# Altium schematic sheet 11_Power.SchDoc(11_Power) (continued)

## Library data of the parts
C224 C225 C234 C235 C243 C244 C246 C250 C251 = Cap_0402: Part Description: 4.7µF ±20% 6.3V X5R Ceramic Capacitor -55°C ~ 85°C Surface Mount, MLCC 0402 (1005 Metric) 0.039" L x 0.020" W (1.00mm x 0.50mm); Manufacturer Part Number 1: GRM155R60J475ME87D; Manufacturer: Murata Electronics North America; Tolerance: ±20%; Temperature Coefficient: X5R; Capacitance: 4.7µF; Voltage - Rated: 6.3V; Value: 4.7uF, 6.3V; Thickness (Max): 0.022" (0.55mm); Supplier Part Number 1: 490-5408-1-ND; Supplier 1: Digi-Key; Size / Dimension: 0.039" L x 0.020" W (1.00mm x 0.50mm); RoHS: RoHS Compliant; Ratings: -; Packaging: Cut Tape (CT); Package / Case: 0402 (1005 Metric); Operating Temperature: -55°C ~ 85°C; Mounting Type: Surface Mount, MLCC; Lead Style: -; Lead Spacing: -; Height - Seated (Max): -; Features: Derating Recommended; Component Kind: Standard; Category: Capacitors; Applications: General Purpose; Library Name: LimeMicroAltiumLib_schLib.SchLib
C226 = Cap_0402: Part Description: 1µF ±10% 10V X5R Ceramic Capacitor -55°C ~ 85°C Surface Mount, MLCC 0402 (1005 Metric) 0.039" L x 0.020" W (1.00mm x 0.50mm); Manufacturer Part Number 1: C1005X5R1E105K050BC; Manufacturer: TDK; Voltage Rating: 10; Product Range: TDK - C Series; Dielectric Characteristic: X6; Ceramic Capacitor Case: 0402 [1005 Metric]; Capacitance Tolerance: ± 10%; Capacitance: 1; Value: 1uF, 10V; Supplier Part Number 1: 445-9066-1-ND; Supplier 1: Digi-Key; RoHS: YES; MSL: -; Component Kind: Standard; Library Name: LimeMicroAltiumLib_schLib.SchLib
C227 C231 C233 C236 C238 C247 C249 C253 C254 C257 = Cap_0603: Part Description: 10 µF ±20% 10V Ceramic Capacitor X5R 0603 (1608 Metric); Manufacturer Part Number 1: GRM188R61A106ME69D; Manufacturer: Murata; Tolerance: 20%; Material: Ceramic; Dielectric: X5R; Width: 0.8mm; Weight: 0.000222oz; Voltage Rating: 10V; Value: 10uF, 10V; Thickness: 0.035inch; Termination: SMD/SMT; Supplier Part Number 1: 490-10475-1-ND; Supplier 1: Digi-Key; Stock 1: 820978; Series: GRM; RoHS Compliant: Yes; RoHS: Yes; Pricing 1: 1=0,16000, 10=0,11200, 50=0,06360, 100=0,05270, 500=0,03696, 1000=0,03060 (USD); Packaging: Tape and Reel; Package Quantity: 4000; Mount: Surface Mount; Min Operating Temperature: -55°C; Max Operating Temperature: 85°C; Length: 1.6mm; Lead Free: Lead Free; Height: 0.8mm; Depth: 0.8mm; ComponentLink9URL: http://search.murata.co.jp/Ceramy/image/img/A01X/G101/ENG/GRM188R61A106ME69-01.pdf?src-supplier=Digi-Key; ComponentLink9Description: http://search.murata.co.jp/Ceramy/image/img/A01X/G101/ENG/GRM188R61A106ME69-01.pdf?src-supplier=Digi-Key; ComponentLink8URL: http://www.farnell.com/datasheets/1872210.pdf?src-supplier=Element14; ComponentLink8Description: http://www.farnell.com/datasheets/1872210.pdf?src-supplier=Element14; ComponentLink7URL: http://www.farnell.com/datasheets/2048014.pdf?src-supplier=Element14; ComponentLink7Description: http://www.farnell.com/datasheets/2048014.pdf?src-supplier=Element14; ComponentLink6URL: http://www.farnell.com/datasheets/1872210.pdf?src-supplier=Newark; ComponentLink6Description: http://www.farnell.com/datasheets/1872210.pdf?src-supplier=Newark; ComponentLink5URL: http://www.mouser.com/ds/2/281/c02e-2905.pdf?src-supplier=Mouser; ComponentLink5Description: http://www.mouser.com/ds/2/281/c02e-2905.pdf?src-supplier=Mouser; ComponentLink4URL: http://www.farnell.com/datasheets/1872210.pdf?src-supplier=Farnell; ComponentLink4Description: http://www.farnell.com/datasheets/1872210.pdf?src-supplier=Farnell; ComponentLink3URL: http://search.murata.co.jp/Ceramy/image/img/A01X/partnumbering_e_01.pdf?src-supplier=Digi-Key; ComponentLink3Description: http://search.murata.co.jp/Ceramy/image/img/A01X/partnumbering_e_01.pdf?src-supplier=Digi-Key; ComponentLink2URL: https://search.murata.co.jp/Ceramy/image/img/A01X/G101/ENG/GRM188R61C106MAAL-01.pdf?src-supplier=Digi-Key; ComponentLink2Description: https://search.murata.co.jp/Ceramy/image/img/A01X/G101/ENG/GRM188R61C106MAAL-01.pdf?src-supplier=Digi-Key; ComponentLink1URL: http://search.murata.co.jp/Ceramy/image/img/A01X/G101/ENG/GRM188R61C106MAAL-01.pdf?src-supplier=Digi-Key; ComponentLink1Description: http://search.murata.co.jp/Ceramy/image/img/A01X/G101/ENG/GRM188R61C106MAAL-01.pdf?src-supplier=Digi-Key; Component Kind: Standard; Case Code (Metric): 1608; Case Code (Imperial): 0603; Case/Package: 0603; Capacitance: 10uF; Library Name: LimeFPGAboard_schLib.SchLib
  C227 C247: Voltage Rating (DC): 10V
C228 C229 C240 C258 = Cap_0402: Part Description: 0.1 µF ±20% 10V Ceramic Capacitor X5R 0201 (0603 Metric); Manufacturer Part Number 1: CL03A104MP3NNNC; Manufacturer: Samsung; Tolerance: 20%; Width: 0.012inch; Voltage Rating: 10V; Value: 100nF, 10V; Thickness: 0.013inch; Supplier Part Number 1: 1276-1321-1-ND; Supplier 1: Digi-Key; Stock 1: 3817176; Series: CL; RoHS Compliant: Yes; RoHS: Yes; Pricing 1: 1=0,10000, 10=0,03000, 50=0,01640, 100=0,01330, 500=0,00950, 1000=0,00747, 2500=0,00679, 5000=0,00625 (USD); Packaging: Tape and Reel; Package Quantity: 1; Mount: Surface Mount; Min Operating Temperature: -55°C; Max Operating Temperature: 85°C; Length: 0.024inch; ComponentLink5URL: https://datasheet.ciiva.com/25788/cl03a104mp3nnnc-25788272.pdf?src-supplier=Digi-Key; ComponentLink5Description: https://datasheet.ciiva.com/25788/cl03a104mp3nnnc-25788272.pdf?src-supplier=Digi-Key; Component Kind: Standard; Case Code (Metric): 0603; Case Code (Imperial): 0201; Case/Package: 0201; Capacitance: 100nF; Library Name: LimeMicroAltiumLib_schLib.SchLib
C230 C239 C245 C256 = Cap_0402: Part Description: 100 pF ±5% 50V Ceramic Capacitor C0G, NP0 0201 (0603 Metric); Manufacturer Part Number 1: C0603C0G1H101J030BA; Manufacturer: TDK; Tolerance: 5%; Insulation Resistance: 10GR; Dielectric Material: Ceramic; Dielectric: C0G; Width: 0.012inch; Weight: 6E-06oz; Voltage Rating: 50V; Voltage: 50V; Value: 100pF, 50V; Thickness: 0.013inch; Termination: SMD/SMT; Supplier Part Number 1: 445-1786-1-ND; Supplier 1: Digi-Key; Stock 1: 367528; Series: C; RoHS Compliant: Yes; RoHS: Yes; Reach SVHC Compliant: Yes; Pricing 1: 1=0,10000, 10=0,06000, 50=0,03280, 100=0,02670, 500=0,01908, 1000=0,01500, 2500=0,01364, 5000=0,01254 (USD); Packaging: Tape and Reel; Package Quantity: 1; Number of Pins: 2; Mount: Surface Mount; Min Operating Temperature: -55°C; Max Operating Temperature: 125°C; Length: 0.6mm; Lead Free: Lead Free; Height: 0.3mm; Depth: 0.3mm; Construction: Flat; ComponentLink3URL: https://datasheet.ciiva.com/6250/tdkmlcccaprange-6250351.pdf?src-supplier=Digi-Key; ComponentLink3Description: https://datasheet.ciiva.com/6250/tdkmlcccaprange-6250351.pdf?src-supplier=Digi-Key; ComponentLink2URL: https://datasheet.ciiva.com/16205/mlcc-commercial-general-en-16205590.pdf?src-supplier=Future+Electronics; ComponentLink2Description: https://datasheet.ciiva.com/16205/mlcc-commercial-general-en-16205590.pdf?src-supplier=Future+Electronics; ComponentLink1URL: https://datasheet.ciiva.com/24217/c0603c0g1h101j030ba-264106-24217425.pdf?src-supplier=Mouser; ComponentLink1Description: https://datasheet.ciiva.com/24217/c0603c0g1h101j030ba-264106-24217425.pdf?src-supplier=Mouser; Component Kind: Standard; Case Code (Metric): 0603; Case Code (Imperial): 0201; Case/Package: 0201; Capacitance: 100pF; Library Name: LimeMicroAltiumLib_schLib.SchLib
C232 C237 C241 C242 C252 C255 C259 C260 = Cap_0603: Part Description: 47 µF ±20% 6.3V Ceramic Capacitor X5R 0603 (1608 Metric); Manufacturer Part Number 1: GRM188R60J476ME15D; Manufacturer: Murata; Tolerance: 20%; Dielectric: X5R; Width: 0.031inch; Voltage Rating: 6.3V; Value: 47uF, 6.3V; Thickness: 0.039inch; Supplier Part Number 1: 490-13247-1-ND; Supplier 1: Digi-Key; Stock 1: 731453; RoHS Compliant: Yes; RoHS: Yes; Pricing 1: 1=0,45000, 10=0,31900, 50=0,24120, 100=0,21000, 500=0,15556, 1000=0,13223 (USD); Packaging: Tape and Reel; Mount: Surface Mount; Min Operating Temperature: -55°C; Max Operating Temperature: 85°C; Length: 0.063inch; ComponentLink2URL: https://www.murata.com/~/media/webrenewal/support/library/catalog/products/capacitor/mlcc/c02e.ashx?la=en-us&src-supplier=Digi-Key; ComponentLink2Description: https://www.murata.com/~/media/webrenewal/support/library/catalog/products/capacitor/mlcc/c02e.ashx?la=en-us&src-supplier=Digi-Key; ComponentLink1URL: http://search.murata.co.jp/Ceramy/image/img/A01X/G101/ENG/GRM188R60J476ME15-01.pdf?src-supplier=Digi-Key; ComponentLink1Description: http://search.murata.co.jp/Ceramy/image/img/A01X/G101/ENG/GRM188R60J476ME15-01.pdf?src-supplier=Digi-Key; Component Kind: Standard; Case Code (Metric): 1608; Case Code (Imperial): 0603; Case/Package: 0603; Capacitance: 47uF; Library Name: LimeFPGAboard_schLib.SchLib
C248 C261 C262 = Cap_0402: Part Description: 1µF ±20% 6.3V X5R Ceramic Capacitor -55°C ~ 85°C Surface Mount, MLCC 0201 (0603 Metric) 0.024" L x 0.012" W (0.60mm x 0.30mm); Manufacturer Part Number 1: JMK063ABJ105MP-F; Manufacturer: TDK Corporation; Value: 1uF, 20%; Tolerance: ±20%; Temperature Coefficient: X5R; Capacitance: 1.0µF; Thickness (Max): -; Supplier Part Number 1: 587-5864-2-ND; Supplier 1: Digi-Key; Size / Dimension: 0.024" L x 0.012" W (0.60mm x 0.30mm); RoHS: RoHS Compliant; Ratings: -; Packaging: Cut Tape (CT); Package / Case: 0201 (0603 Metric); Operating Temperature: -55°C ~ 85°C; Mounting Type: Surface Mount, MLCC; Lead Style: -; Lead Spacing: -; Height - Seated (Max): -; Features: -; Component Kind: Standard; Category: Capacitors; Applications: General Purpose; Library Name: LimeMicroAltiumLib_schLib.SchLib
FR44 FR46-FR50 = Ferrite: Part Description: FERRITE BEAD 220 OHM 0402 1LN; Manufacturer Part Number 1: MPZ1005S221ET000; Manufacturer: TDK Corporation; Impedance @ Frequency: 220 Ohm @ 100MHz; DC Resistance (DCR): 220 mOhm Max; Current Rating: 900mA; Value: 220R, 0.9A; Supplier Part Number 1: 445-9329-2-ND; Supplier 1: Digi-Key; Package / Case: 0402 (1005 Metric); Component Kind: Standard; Category: Filters; Library Name: LimeFPGAboard_schLib.SchLib
FR45 = Ferrite: Part Description: FERRITE BEAD 600 OHM 0805 1LN; Manufacturer Part Number 1: MPZ2012S601AT000; Manufacturer: TDK Corporation; Impedance @ Frequency: 600 Ohm @ 100MHz; Filter Type: Differential Mode - Single; DC Resistance (DCR): 100 mOhm Max; Supplier Part Number 1: 445-2206-2-ND; Supplier 1: Digi-Key; Size / Dimension: 0.079" L x 0.049" W (2.00mm x 1.25mm); RoHS: RoHS Compliant; Packaging: Tape & Reel (TR); Package / Case: 0805 (2012 Metric); Mounting Type: Surface Mount; Height (Max): 0.041" (1.05mm); Component Kind: Standard; Category: Filters; Library Name: LimeMicroAltiumLib_schLib.SchLib
IC22 IC26-IC28 = LD39100PUR: Part Description: Linear Voltage Regulator IC Positive Adjustable Output 0.8 V ~ 4.5 V 1A 6-DFN (3x3); Manufacturer: STMicroelectronics; Voltage Dropout (Max): 0.4V @ 1A; Voltage - Output (Min/Fixed): 0.8V; Voltage - Output (Max): 4.6V; Voltage - Input (Max): 5.5V; PSRR: 70dB ~ 65dB (1kHz ~ 10kHz); Protection Features: Over Current, Over Temperature, Short Circuit; Output Type: Adjustable; Output Configuration: Positive; Current - Supply: 50µA ~ 300µA; Current - Output: 1A; Control Features: Enable, Power Good; Supplier Part Number 1: 497-11013-1-ND; Supplier Device Package: 6-DFN (3x3); Supplier 1: Digi-Key; Stock 1: 27970; RoHS: RoHS Compliant; Pricing 1: 1=0.85, 10=0.76, 100=0.5923, 500=0.48926, 1000=0.38625 (USD); Part Status: Active; Packaging: Cut Tape (CT); Package / Case: 6-VDFN Exposed Pad; Operating Temperature: -40°C ~ 125°C; Mounting Type: Surface Mount; Manufacturer Part Number 1: LD39100PUR; ComponentLink1URL: http://www.st.com/content/ccc/resource/technical/document/datasheet/72/02/e5/ee/df/36/40/5e/CD00233788.pdf/files/CD00233788.pdf/jcr:content/translations/en.CD00233788.pdf; ComponentLink1Description: http://www.st.com/content/ccc/resource/technical/document/datasheet/72/02/e5/ee/df/36/40/5e/CD00233788.pdf/files/CD00233788.pdf/jcr:content/translations/en.CD00233788.pdf; Component Kind: Standard; Category: Integrated Circuits (ICs); Library Name: LimeMicroAltiumLib_schLib.SchLib
IC23 = 74LVC1G3157FZ4_SPDT_SW: Part Description: 1 Circuit IC Switch 2:1 10Ohm X2-DFN1410-6; Manufacturer Part Number 1: 74LVC1G3157FZ4-7; Manufacturer: Diodes; On-State Resistance: 10R; Number of Circuits: 1; -3db Bandwidth: 300MHz; Supplier Part Number 1: 74LVC1G3157FZ4-7DICT-ND; Supplier 1: Digi-Key; Stock 1: 158709; RoHS Compliant: Yes; RoHS: Yes; Pricing 1: 1=0,42000, 10=0,31200, 25=0,27320, 100=0,17670, 250=0,14628, 500=0,11702, 1000=0,08972, 2500=0,07802 (USD); Packaging: Tape and Reel; Number of Pins: 6; Min Operating Temperature: -40°C; Max Operating Temperature: 125°C; ComponentLink2URL: https://www.diodes.com/assets/product-showcases/74LVC3157-NPA-0516.pdf?src-supplier=Digi-Key; ComponentLink2Description: https://www.diodes.com/assets/product-showcases/74LVC3157-NPA-0516.pdf?src-supplier=Digi-Key; ComponentLink1URL: https://www.diodes.com/assets/Datasheets/74LVC1G3157.pdf?src-supplier=Digi-Key; ComponentLink1Description: https://www.diodes.com/assets/Datasheets/74LVC1G3157.pdf?src-supplier=Digi-Key; Component Kind: Standard; Library Name: LimeMicroAltiumLib_schLib_r04.SchLib
IC24 = VOLTAGE_REFERENCE_UNIVERSAL: Part Description: Shunt Voltage Reference IC Adjustable 2.5V 36 VV ±0.5% 100 mA SOT-23-3; Manufacturer Part Number 1: AS431ANTR-G1; Manufacturer: Diodes; Value: AS431; Supplier Part Number 1: AS431ANTR-G1DICT-ND; Supplier 1: Digikey; Stock 1: 655820; RoHS: Yes; Pricing 1: 1=0,32, 10=0,196, 25=0,162, 100=0,1226, 250=0,10304, 500=0,09098, 1000=0,08085 (USD); ComponentLink7URL: https://datasheet.ciiva.com/29572/as431-29572150.pdf?src-supplier=Digikey; ComponentLink7Description: https://datasheet.ciiva.com/29572/as431-29572150.pdf?src-supplier=Digikey; ComponentLink6URL: https://datasheet.ciiva.com/29572/as431-29572149.pdf?src-supplier=Digikey; ComponentLink6Description: https://datasheet.ciiva.com/29572/as431-29572149.pdf?src-supplier=Digikey; ComponentLink5URL: https://datasheet.ciiva.com/8132/lm4040-8132551.pdf?src-supplier=Avnet; ComponentLink5Description: https://datasheet.ciiva.com/8132/lm4040-8132551.pdf?src-supplier=Avnet; ComponentLink4URL: https://datasheet.ciiva.com/29572/as431-29572150.pdf?src-supplier=Digi-Key; ComponentLink4Description: https://datasheet.ciiva.com/29572/as431-29572150.pdf?src-supplier=Digi-Key; ComponentLink3URL: https://datasheet.ciiva.com/29572/as431-29572149.pdf?src-supplier=Digi-Key; ComponentLink3Description: https://datasheet.ciiva.com/29572/as431-29572149.pdf?src-supplier=Digi-Key; ComponentLink2URL: https://datasheet.ciiva.com/30002/as431-30002029.pdf?src-supplier=Avnet; ComponentLink2Description: https://datasheet.ciiva.com/30002/as431-30002029.pdf?src-supplier=Avnet; ComponentLink1URL: https://datasheet.ciiva.com/1177/1643148-1177233.pdf?src-supplier=Avnet; ComponentLink1Description: https://datasheet.ciiva.com/1177/1643148-1177233.pdf?src-supplier=Avnet; Component Kind: Standard; Library Name: LimeMicroAltiumLib_schLib_r05.SchLib
IC25 = LP8758A1E0YFFR_QUAD_DC-DC: Part Description: Buck Switching Regulator IC Positive Programmable 0.5V 4 Output 4A 35-UFBGA, DSBGA; Manufacturer: Texas Instruments; Supplier Part Number 1: 296-LP8758A2E3YFFRCT-ND; Supplier 1: Digi-Key; Stock 1: 5990; RoHS: Yes; Pricing 1: 1=5,83, 10=5,235, 25=4,9496, 100=4,2896, 250=4,0696, 500=3,65164, 1000=3,07969 (USD); Manufacturer Part Number 1: LP8758A2E3YFFR; ComponentLink3URL: http://www.ti.com/lit/ds/symlink/lp8758-e0.pdf?src-supplier=Digi-Key; ComponentLink3Description: http://www.ti.com/lit/ds/symlink/lp8758-e0.pdf?src-supplier=Digi-Key; ComponentLink2URL: http://www.ti.com/lit/gpn/lp8758-e0?src-supplier=Mouser; ComponentLink2Description: http://www.ti.com/lit/gpn/lp8758-e0?src-supplier=Mouser; ComponentLink1URL: http://www.ti.com/general/docs/suppproductinfo.tsp?distId=10&amp;gotoUrl=http%3A%2F%2Fwww.ti.com%2Flit%2Fgpn%2Flp8758-e0&src-supplier=Digi-Key; ComponentLink1Description: http://www.ti.com/general/docs/suppproductinfo.tsp?distId=10&amp;gotoUrl=http%3A%2F%2Fwww.ti.com%2Flit%2Fgpn%2Flp8758-e0&src-supplier=Digi-Key; Component Kind: Standard; Library Name: LimeMicroAltiumLib_schLib_r04.SchLib
IC29 = LP8758A1E0YFFR_QUAD_DC-DC: Part Description: Switching Voltage Regulators Four-phase, four 4A output DC-DC step-down converters 35-DSBGA -40 to 85; Manufacturer: Texas Instruments; Supplier Part Number 1: 296-LP8758A2E3YFFRCT-ND; Supplier 1: Digi-Key; Stock 1: 5990; RoHS: Yes; Pricing 1: 1=5,83, 10=5,235, 25=4,9496, 100=4,2896, 250=4,0696, 500=3,65164, 1000=3,07969 (USD); Manufacturer Part Number 1: LP8758A2E3YFFR; ComponentLink3URL: http://www.ti.com/lit/ds/symlink/lp8758-e0.pdf?src-supplier=Digi-Key; ComponentLink3Description: http://www.ti.com/lit/ds/symlink/lp8758-e0.pdf?src-supplier=Digi-Key; ComponentLink2URL: http://www.ti.com/lit/gpn/lp8758-e0?src-supplier=Mouser; ComponentLink2Description: http://www.ti.com/lit/gpn/lp8758-e0?src-supplier=Mouser; ComponentLink1URL: http://www.ti.com/general/docs/suppproductinfo.tsp?distId=10&amp;gotoUrl=http%3A%2F%2Fwww.ti.com%2Flit%2Fgpn%2Flp8758-e0&src-supplier=Digi-Key; ComponentLink1Description: http://www.ti.com/general/docs/suppproductinfo.tsp?distId=10&amp;gotoUrl=http%3A%2F%2Fwww.ti.com%2Flit%2Fgpn%2Flp8758-e0&src-supplier=Digi-Key; Component Kind: Standard; Library Name: LimeMicroAltiumLib_schLib_r04.SchLib
IC30 = AP7330-W5-7_LDO: Part Description: Linear Voltage Regulator IC Positive Adjustable 1 Output 300mA SOT-25; Manufacturer: Diodes; Supplier Part Number 1: AP7330-W5-7DICT-ND; Supplier 1: Digi-Key; Stock 1: 50531; RoHS: Yes; Pricing 1: 1=0,35, 10=0,286, 25=0,2616, 100=0,1946, 250=0,17632, 500=0,14592, 1000=0,10944 (USD); Manufacturer Part Number 1: AP7330-W5-7; ComponentLink2URL: https://www.diodes.com/assets/Datasheets/AP7330.pdf?src-supplier=Digi-Key; ComponentLink2Description: https://www.diodes.com/assets/Datasheets/AP7330.pdf?src-supplier=Digi-Key; ComponentLink1URL: https://datasheet.octopart.com/AP7330-W5-7-Diodes-Inc.-datasheet-149521347.pdf?src-supplier=Farnell; ComponentLink1Description: https://datasheet.octopart.com/AP7330-W5-7-Diodes-Inc.-datasheet-149521347.pdf?src-supplier=Farnell; Component Kind: Standard; Library Name: LimeMicroAltiumLib.SchLib
L2-L9 = Inductor: Part Description: 470 nH Shielded Drum Core, Wirewound Inductor 2.81 A 41mOhm Max 0806 (2016 Metric); Manufacturer Part Number 1: VLS201610HBX-R47M-1; Manufacturer: TDK; Tolerance: 20%; Test Frequency: 1MHz; Shielding: Shielded; Saturation Current: 3.5A; RMS Current (Irms): 3.3A; Inductance: 470nH; DC Resistance (DCR): 41mR; Current Rating: 2.81A; Core Material: Metal; Width: 0.063inch; Value: 0.47uH, 4A; Supplier Part Number 1: 445-172395-1-ND; Supplier 1: Digi-Key; Stock 1: 1817; RoHS Compliant: Yes; RoHS: Yes; Resistor Type: Wirewound; Reach SVHC Compliant: No SVHC; Pricing 1: 1=0,35000, 10=0,31200, 25=0,27320, 50=0,23400, 100=0,21450, 250=0,19504, 500=0,18334, 1000=0,14043 (USD); Packaging: Cut Tape; Mount: Surface Mount; Min Operating Temperature: -40°C; Max Operating Temperature: 105°C; Length: 0.079inch; Inductance Tolerance: 20%; Height - Seated (Max): 0.039inch; ComponentLink5URL: https://product.tdk.com/info/en/catalog/datasheets/inductor_commercial_power_vls201610hbx-1_en.pdf?ref_disty=digikey&src-supplier=Digi-Key; ComponentLink5Description: https://product.tdk.com/info/en/catalog/datasheets/inductor_commercial_power_vls201610hbx-1_en.pdf?ref_disty=digikey&src-supplier=Digi-Key; ComponentLink4URL: https://product.tdk.com/info/en/catalog/datasheets/inductor_commercial_power_vls201610hbx-1_en.pdf?src-supplier=Digi-Key; ComponentLink4Description: https://product.tdk.com/info/en/catalog/datasheets/inductor_commercial_power_vls201610hbx-1_en.pdf?src-supplier=Digi-Key; ComponentLink3URL: http://www.farnell.com/datasheets/2130008.pdf?src-supplier=Element14; ComponentLink3Description: http://www.farnell.com/datasheets/2130008.pdf?src-supplier=Element14; ComponentLink2URL: http://www.farnell.com/datasheets/1866267.pdf?src-supplier=Element14; ComponentLink2Description: http://www.farnell.com/datasheets/1866267.pdf?src-supplier=Element14; ComponentLink1URL: http://www.farnell.com/datasheets/2130008.pdf?src-supplier=Farnell; ComponentLink1Description: http://www.farnell.com/datasheets/2130008.pdf?src-supplier=Farnell; Component Kind: Standard; Case Code (Metric): 2016; Case Code (Imperial): 0806; Case/Package: 0806; Library Name: LimeMicroAltiumLib_schLib_r04.SchLib
MECH1 = RFI_SHIELD_COVER_BMI-S-202-C: Part Description: RF Shield Cover 0.668" (16.96mm) X 0.668" (16.96mm) Vent Holes in Pattern Snap Fit; Manufacturer: Laird; Width: 16.5mm; Supplier Part Number 1: 903-1014-ND; Supplier 1: Digi-Key; Stock 1: 245970; Series: SMT 2 Piece; RoHS: Yes; Pricing 1: 1=1,68000, 10=1,51300, 25=1,42760, 100=1,21620, 250=1,14200, 500=0,99924, 1000=0,82795, 2500=0,77085, 5000=0,74230 (USD); Packaging: Bulk; Package Quantity: 1400; Material: Aluminium; Manufacturer Part Number 1: BMI-S-202-C; Length: 16.5mm; Depth: 3.6mm; ComponentLink7URL: http://assets.lairdtech.com/home/brandworld/files/Board%20Level%20Shields%20Catalog%20Download.pdf?src-supplier=Quest; ComponentLink7Description: http://assets.lairdtech.com/home/brandworld/files/Board%20Level%20Shields%20Catalog%20Download.pdf?src-supplier=Quest; ComponentLink6URL: http://assets.lairdtech.com/home/brandworld/files/Board%20Level%20Shields%20Catalog%20Download.pdf?src-supplier=Digi-Key; ComponentLink6Description: http://assets.lairdtech.com/home/brandworld/files/Board%20Level%20Shields%20Catalog%20Download.pdf?src-supplier=Digi-Key; ComponentLink5URL: http://www.farnell.com/datasheets/1965028.pdf?src-supplier=Element14; ComponentLink5Description: http://www.farnell.com/datasheets/1965028.pdf?src-supplier=Element14; ComponentLink4URL: http://www.farnell.com/datasheets/1965028.pdf?src-supplier=Newark; ComponentLink4Description: http://www.farnell.com/datasheets/1965028.pdf?src-supplier=Newark; ComponentLink3URL: http://www.mouser.com/ds/2/223/Laird_BMIS_201_210-201562.pdf?src-supplier=Mouser; ComponentLink3Description: http://www.mouser.com/ds/2/223/Laird_BMIS_201_210-201562.pdf?src-supplier=Mouser; ComponentLink2URL: http://www.farnell.com/datasheets/1965028.pdf?src-supplier=Farnell; ComponentLink2Description: http://www.farnell.com/datasheets/1965028.pdf?src-supplier=Farnell; ComponentLink1URL: https://www.leadertechinc.com/assets/files/EngineeringDrawings/SMS-206.PDF; ComponentLink1Description: https://www.leadertechinc.com/assets/files/EngineeringDrawings/SMS-206.PDF; Component Kind: Standard; Color: Grey; Library Name: LimeMicroAltiumLib_schLib_r04.SchLib
MECH2 = RFI_SHIELD_FRAME_BMI-S-202-F: Part Description: RF Shield Frame 0.650" (16.50mm) X 0.650" (16.50mm) Surface Mount; Manufacturer: Laird; Width: 16.5mm; Supplier Part Number 1: 903-1051-1-ND; Supplier 1: Digi-Key; Stock 1: 239502; RoHS: Yes; Reach SVHC Compliant: Unknown; Pricing 1: 1=1,94000, 10=1,74100, 25=1,64280, 100=1,39970, 250=1,31428 (USD); Packaging: Tape and Reel; Package Quantity: 700; Mount: Solder; Material: Aluminium; Manufacturer Part Number 1: BMI-S-202-F; Length: 16.5mm; Depth: 3.6mm; ComponentLink2URL: https://datasheet.ciiva.com/2799/bmi-s-202-2799404.pdf?src-supplier=Digi-Key; ComponentLink2Description: https://datasheet.ciiva.com/2799/bmi-s-202-2799404.pdf?src-supplier=Digi-Key; ComponentLink1URL: https://www.leadertechinc.com/assets/files/EngineeringDrawings/SMS-206.PDF; ComponentLink1Description: https://www.leadertechinc.com/assets/files/EngineeringDrawings/SMS-206.PDF; Component Kind: Standard; Color: Grey; Library Name: LimeMicroAltiumLib_schLib_r04.SchLib
R187 R191 R193 R194 R200 R203 R206 R208 R210 = Res_0402: Part Description: 0 Ohms Jumper Chip Resistor 0201 (0603 Metric) Moisture Resistant Thick Film; Manufacturer Part Number 1: RC0201JR-070RL; Manufacturer: Yageo; Voltage Rating (DC): 25V; Tolerance: 5%; Power Rating: 50mW; Width: 0.012inch; Weight: 6E-06oz; Voltage Rating: 25V; Value: 0R; Termination: SMD/SMT; Supplier Part Number 1: 311-0.0NCT-ND; Supplier 1: Digi-Key; Stock 1: 6252381; Size Code: 0201; Series: RC; RoHS Compliant: Yes; RoHS: Yes; Resistor Type: Thick Film; Resistance Tolerance: 5%; Resistance: 0R; Radiation Hardening: No; Pricing 1: 1=0,10000, 10=0,03700, 25=0,02680, 50=0,02020, 100=0,01500, 250=0,01140, 500=0,00912, 1000=0,00672, 5000=0,00482 (USD); Packaging: Tape and Reel; Package Quantity: 1; Number of Terminations: 2; Min Operating Temperature: -55°C; Military Standard: Not; Max Power Dissipation: 50mW; Max Operating Temperature: 125°C; Length: 0.024inch; Lead Free: Lead Free; Height: 0.01inch; Features: Moisture Resistant; Depth: 0.3mm; Contact Plating: Tin,Matte; Construction: Rectangular; ComponentLink5URL: https://datasheet.ciiva.com/46391/1421516-46391340.pdf?src-supplier=Element14; ComponentLink5Description: https://datasheet.ciiva.com/46391/1421516-46391340.pdf?src-supplier=Element14; ComponentLink4URL: https://datasheet.ciiva.com/7660/1421516-7660808.pdf?src-supplier=Element14; ComponentLink4Description: https://datasheet.ciiva.com/7660/1421516-7660808.pdf?src-supplier=Element14; ComponentLink3URL: https://datasheet.ciiva.com/7672/1563626-7672247.pdf?src-supplier=Element14; ComponentLink3Description: https://datasheet.ciiva.com/7672/1563626-7672247.pdf?src-supplier=Element14; ComponentLink2URL: https://datasheet.ciiva.com/7672/1563626-7672248.pdf?src-supplier=Element14; ComponentLink2Description: https://datasheet.ciiva.com/7672/1563626-7672248.pdf?src-supplier=Element14; ComponentLink1URL: http://www.yageo.com/documents/recent/PYu-RC_Group_51_RoHS_L_7.pdf; ComponentLink1Description: http://www.yageo.com/documents/recent/PYu-RC_Group_51_RoHS_L_7.pdf; Component Kind: Standard; Case Code (Metric): 0603; Case Code (Imperial): 0201; Case/Package: 0201; Library Name: LimeFPGAboard_schLib.SchLib
R188 R192 R196 R209 R212 = Res_0402: Part Description: 10 kOhms ±1% 0.05W, 1/20W Chip Resistor 0201 (0603 Metric) Moisture Resistant Thick Film; Manufacturer Part Number 1: RC0201FR-0710KL; Manufacturer: Yageo; Working Voltage: 25V; Temperature Coefficient: 200ppm/°C; Resistance: 10kR; Power Rating: 50mW; Width: 0.012inch; Weight: 6E-06oz; Voltage Rating (DC): 25V; Voltage Rating: 25V; Value: 10k, 1%; Termination: SMD/SMT; Technology: THICK FILM; Supplier Part Number 1: 311-10.0KMCT-ND; Supplier 1: Digi-Key; Stock 1: 17142315; Series: RC; RoHS Compliant: Yes; RoHS: Yes; Resistor Type: Thick Film; Resistance Tolerance: 1%; Reach SVHC Compliant: Yes; Radiation Hardening: No; Pricing 1: 1=0,10000, 10=0,03700, 100=0,01530, 1000=0,00685, 2500=0,00595, 5000=0,00491 (USD); Packaging: Tape and Reel; Package Quantity: 10000; Number of Terminations: 2; Number of Pins: 2; Min Operating Temperature: -55°C; Military Standard: Not; Max Power Dissipation: 50mW; Max Operating Temperature: 125°C; Length: 0.6mm; Lead Free: Lead Free; Height: 0.01inch; Features: Moisture Resistant; Depth: 0.3mm; Contact Plating: Tin,Matte; Construction: Rectangular; ComponentLink7URL: https://datasheet.ciiva.com/2467/pyu-r-int-thick-7-2467109.pdf?src-supplier=Digi-Key; ComponentLink7Description: https://datasheet.ciiva.com/2467/pyu-r-int-thick-7-2467109.pdf?src-supplier=Digi-Key; ComponentLink6URL: https://datasheet.ciiva.com/2467/pyu-r-int-thick-7-2467111.pdf?src-supplier=Digi-Key; ComponentLink6Description: https://datasheet.ciiva.com/2467/pyu-r-int-thick-7-2467111.pdf?src-supplier=Digi-Key; ComponentLink5URL: https://datasheet.ciiva.com/2467/pyu-r-int-thick-7-2467110.pdf?src-supplier=Digi-Key; ComponentLink5Description: https://datasheet.ciiva.com/2467/pyu-r-int-thick-7-2467110.pdf?src-supplier=Digi-Key; ComponentLink4URL: https://datasheet.ciiva.com/46391/1421516-46391339.pdf?src-supplier=Element14; ComponentLink4Description: https://datasheet.ciiva.com/46391/1421516-46391339.pdf?src-supplier=Element14; ComponentLink3URL: https://datasheet.ciiva.com/7660/1421516-7660807.pdf?src-supplier=Element14; ComponentLink3Description: https://datasheet.ciiva.com/7660/1421516-7660807.pdf?src-supplier=Element14; ComponentLink2URL: https://datasheet.ciiva.com/22661/1563626-22661319.pdf?src-supplier=Element14; ComponentLink2Description: https://datasheet.ciiva.com/22661/1563626-22661319.pdf?src-supplier=Element14; ComponentLink1URL: https://datasheet.ciiva.com/5924/1563626-5924912.pdf?src-supplier=Farnell; ComponentLink1Description: https://datasheet.ciiva.com/5924/1563626-5924912.pdf?src-supplier=Farnell; Component Kind: Standard; Case Code (Metric): 0603; Case Code (Imperial): 0201; Case/Package: 0201; Library Name: LimeFPGAboard_schLib.SchLib
R189 = Res_0402: Part Description: 5.6 kOhms ±1% 0.05W, 1/20W Chip Resistor 0201 (0603 Metric) Moisture Resistant Thick Film; Manufacturer Part Number 1: RC0201FR-075K6L; Manufacturer: Yageo; Voltage Rating: 25V; Temperature Coefficient: 200ppm/°C; Resistance: 5.6kR; Power Rating: 50mW; Width: 0.3mm; Value: 5.6k, 1%; Termination: SMD/SMT; Supplier Part Number 1: YAG2346CT-ND; Supplier 1: Digi-Key; Stock 1: 808399; Series: RC; RoHS Compliant: Yes; RoHS: Yes; Resistor Type: Thick Film; Resistance Tolerance: 1%; Reach SVHC Compliant: Yes; Pricing 1: 1=0,10000, 10=0,03700, 100=0,01500, 1000=0,00672, 2500=0,00583, 5000=0,00482 (USD); Packaging: Tape and Reel; Package Quantity: 10000; Number of Terminations: 2; Min Operating Temperature: -55°C; Max Power Dissipation: 50mW; Max Operating Temperature: 125°C; Length: 0.024inch; Height: 0.01inch; Features: Moisture Resistant; ComponentLink8URL: https://datasheet.ciiva.com/22661/1563626-22661325.pdf?src-supplier=Element14; ComponentLink8Description: https://datasheet.ciiva.com/22661/1563626-22661325.pdf?src-supplier=Element14; ComponentLink7URL: https://datasheet.ciiva.com/2467/pyu-r-int-thick-7-2467109.pdf?src-supplier=Digi-Key; ComponentLink7Description: https://datasheet.ciiva.com/2467/pyu-r-int-thick-7-2467109.pdf?src-supplier=Digi-Key; ComponentLink6URL: https://datasheet.ciiva.com/2467/pyu-r-int-thick-7-2467111.pdf?src-supplier=Digi-Key; ComponentLink6Description: https://datasheet.ciiva.com/2467/pyu-r-int-thick-7-2467111.pdf?src-supplier=Digi-Key; ComponentLink5URL: https://datasheet.ciiva.com/2467/pyu-r-int-thick-7-2467110.pdf?src-supplier=Digi-Key; ComponentLink5Description: https://datasheet.ciiva.com/2467/pyu-r-int-thick-7-2467110.pdf?src-supplier=Digi-Key; ComponentLink4URL: https://datasheet.ciiva.com/46391/1421516-46391339.pdf?src-supplier=Element14; ComponentLink4Description: https://datasheet.ciiva.com/46391/1421516-46391339.pdf?src-supplier=Element14; ComponentLink3URL: https://datasheet.ciiva.com/7660/1421516-7660807.pdf?src-supplier=Element14; ComponentLink3Description: https://datasheet.ciiva.com/7660/1421516-7660807.pdf?src-supplier=Element14; ComponentLink2URL: https://datasheet.ciiva.com/22661/1563626-22661319.pdf?src-supplier=Element14; ComponentLink2Description: https://datasheet.ciiva.com/22661/1563626-22661319.pdf?src-supplier=Element14; ComponentLink1URL: https://datasheet.ciiva.com/5924/1563626-5924912.pdf?src-supplier=Farnell; ComponentLink1Description: https://datasheet.ciiva.com/5924/1563626-5924912.pdf?src-supplier=Farnell; Component Kind: Standard; Case Code (Metric): 0603; Case Code (Imperial): 0201; Case/Package: 0201; Library Name: LimeFPGAboard_schLib.SchLib
R190 = Res_0402: Part Description: 330 Ohms ±1% 0.05W, 1/20W Chip Resistor 0201 (0603 Metric) Thick Film; Manufacturer Part Number 1: CRCW0201330RFKED; Manufacturer: Vishay Dale; Voltage Rating: 30V; Temperature Coefficient: 100ppm/°C; Power Rating: 50mW; Width: 0.3mm; Weight: 6E-06oz; Value: 330R, 1%; Supplier Part Number 1: 541-330AABCT-ND; Supplier 1: Digi-Key; Stock 1: 245078; Size Code: 0201; Series: CRCW; RoHS Compliant: Yes; RoHS: Yes; Resistor Type: Thick Film; Resistance: 330R; Radiation Hardening: No; Pricing 1: 1=0,27000, 10=0,23000, 100=0,08990, 1000=0,03762, 2500=0,03448, 5000=0,03135 (USD); Packaging: Tape and Reel; Package Quantity: 1; Number of Terminations: 2; Min Operating Temperature: -55°C; Military Standard: Not; Max Power Dissipation: 50mW; Max Operating Temperature: 155°C; Length: 0.6mm; Lead Free: Lead Free; Height: 0.011inch; Depth: 0.3mm; Contact Plating: Tin; Construction: Rectangular; ComponentLink5URL: https://datasheet.ciiva.com/16394/dcrcwe3-16394575.pdf?src-supplier=Future+Electronics; ComponentLink5Description: https://datasheet.ciiva.com/16394/dcrcwe3-16394575.pdf?src-supplier=Future+Electronics; ComponentLink4URL: https://datasheet.ciiva.com/2473/crcw0201e3-2473438.pdf?src-supplier=Digi-Key; ComponentLink4Description: https://datasheet.ciiva.com/2473/crcw0201e3-2473438.pdf?src-supplier=Digi-Key; ComponentLink3URL: https://datasheet.ciiva.com/2473/crcw0201e3-2473437.pdf?src-supplier=Digi-Key; ComponentLink3Description: https://datasheet.ciiva.com/2473/crcw0201e3-2473437.pdf?src-supplier=Digi-Key; ComponentLink2URL: https://datasheet.ciiva.com/2473/crcw0201e3-2473436.pdf?src-supplier=Digi-Key; ComponentLink2Description: https://datasheet.ciiva.com/2473/crcw0201e3-2473436.pdf?src-supplier=Digi-Key; ComponentLink1URL: https://datasheet.ciiva.com/8944/crcw0201e3-8944937.pdf?src-supplier=Avnet; ComponentLink1Description: https://datasheet.ciiva.com/8944/crcw0201e3-8944937.pdf?src-supplier=Avnet; Component Kind: Standard; Case Code (Metric): 0603; Case Code (Imperial): 0201; Case/Package: 0201; Library Name: LimeFPGAboard_schLib.SchLib
R195 = Res_0402: Part Description: 7.5 kOhms ±1% 0.05W, 1/20W Chip Resistor 0201 (0603 Metric) Thick Film; Manufacturer Part Number 1: RMCF0201FT7K50; Manufacturer: Stackpole Electronics; Temperature Coefficient: 200ppm/°C; Resistance: 7.5kR; Max Power Dissipation: 50mW; Width: 0.012inch; Value: 7.5k, 1%; Supplier Part Number 1: RMCF0201FT7K50CT-ND; Supplier 1: Digi-Key; Stock 1: 145930; Series: RMCF; RoHS Compliant: Yes; RoHS: Yes; Resistor Type: Thick Film; Radiation Hardening: No; Pricing 1: 1=0,10000, 10=0,02400, 100=0,00970, 1000=0,00437, 2500=0,00379, 5000=0,00313 (USD); Packaging: Tape and Reel; Package Quantity: 10000; Number of Terminations: 2; Mount: Surface Mount; Min Operating Temperature: -55°C; Max Operating Temperature: 125°C; Length: 0.024inch; Height: 0.009inch; Contact Plating: Tin,Matte; ComponentLink11URL: http://www.seielect.com/Catalog/SEI-RMCF_RMCP.pdf?src-supplier=Digi-Key; ComponentLink11Description: http://www.seielect.com/Catalog/SEI-RMCF_RMCP.pdf?src-supplier=Digi-Key; ComponentLink10URL: https://www.seielect.com/catalog/SEI-RMCF_RMCP.pdf?src-supplier=Avnet; ComponentLink10Description: https://www.seielect.com/catalog/SEI-RMCF_RMCP.pdf?src-supplier=Avnet; ComponentLink9URL: https://www.seielect.com/Catalog/SEI-RMCF_RMCP.pdf?src-supplier=Digi-Key; ComponentLink9Description: https://www.seielect.com/Catalog/SEI-RMCF_RMCP.pdf?src-supplier=Digi-Key; ComponentLink8URL: https://www.seielect.com/catalog/SEI-Packaging.pdf?src-supplier=Digi-Key; ComponentLink8Description: https://www.seielect.com/catalog/SEI-Packaging.pdf?src-supplier=Digi-Key; ComponentLink7URL: https://datasheet.ciiva.com/2467/pyu-r-int-thick-7-2467109.pdf?src-supplier=Digi-Key; ComponentLink7Description: https://datasheet.ciiva.com/2467/pyu-r-int-thick-7-2467109.pdf?src-supplier=Digi-Key; ComponentLink6URL: https://datasheet.ciiva.com/2467/pyu-r-int-thick-7-2467111.pdf?src-supplier=Digi-Key; ComponentLink6Description: https://datasheet.ciiva.com/2467/pyu-r-int-thick-7-2467111.pdf?src-supplier=Digi-Key; ComponentLink5URL: https://datasheet.ciiva.com/2467/pyu-r-int-thick-7-2467110.pdf?src-supplier=Digi-Key; ComponentLink5Description: https://datasheet.ciiva.com/2467/pyu-r-int-thick-7-2467110.pdf?src-supplier=Digi-Key; ComponentLink4URL: https://datasheet.ciiva.com/46391/1421516-46391339.pdf?src-supplier=Element14; ComponentLink4Description: https://datasheet.ciiva.com/46391/1421516-46391339.pdf?src-supplier=Element14; ComponentLink3URL: https://datasheet.ciiva.com/7660/1421516-7660807.pdf?src-supplier=Element14; ComponentLink3Description: https://datasheet.ciiva.com/7660/1421516-7660807.pdf?src-supplier=Element14; ComponentLink2URL: https://datasheet.ciiva.com/22661/1563626-22661319.pdf?src-supplier=Element14; ComponentLink2Description: https://datasheet.ciiva.com/22661/1563626-22661319.pdf?src-supplier=Element14; ComponentLink1URL: https://datasheet.ciiva.com/5924/1563626-5924912.pdf?src-supplier=Farnell; ComponentLink1Description: https://datasheet.ciiva.com/5924/1563626-5924912.pdf?src-supplier=Farnell; Component Kind: Standard; Case Code (Metric): 0603; Case Code (Imperial): 0201; Case/Package: 0201; Library Name: LimeFPGAboard_schLib.SchLib
R197 R213 R215 R216 = Res_0402: Part Description: 3.3 kOhms ±1% 0.05W, 1/20W Chip Resistor 0201 (0603 Metric) Moisture Resistant Thick Film; Manufacturer Part Number 1: RC0201FR-073K3L; Manufacturer: Yageo; Voltage Rating: 25V; Temperature Coefficient: 200ppm/°C; Resistance: 3.3kR; Power Rating: 50mW; Width: 0.3mm; Value: 3k3, 1%; Termination: SMD/SMT; Supplier Part Number 1: YAG2285CT-ND; Supplier 1: Digi-Key; Stock 1: 586100; Series: RC; RoHS Compliant: Yes; RoHS: Yes; Resistor Type: Thick Film; Resistance Tolerance: 1%; Pricing 1: 1=0,10000, 10=0,03700, 100=0,01500, 1000=0,00672, 2500=0,00583, 5000=0,00482 (USD); Packaging: Tape and Reel; Package Quantity: 10000; Number of Terminations: 2; Min Operating Temperature: -55°C; Military Standard: Not; Max Power Dissipation: 50mW; Max Operating Temperature: 125°C; Length: 0.024inch; Height: 0.01inch; Features: Moisture Resistant; Depth: 0.3mm; Construction: Rectangular; ComponentLink3URL: https://datasheet.ciiva.com/14985/pyu-r-int-thick-7-14985418.pdf?src-supplier=Digi-Key; ComponentLink3Description: https://datasheet.ciiva.com/14985/pyu-r-int-thick-7-14985418.pdf?src-supplier=Digi-Key; ComponentLink2URL: https://datasheet.ciiva.com/22698/1563626-22698524.pdf?src-supplier=Element14; ComponentLink2Description: https://datasheet.ciiva.com/22698/1563626-22698524.pdf?src-supplier=Element14; ComponentLink1URL: http://www.yageo.com/documents/recent/PYu-RC_Group_51_RoHS_L_7.pdf; ComponentLink1Description: http://www.yageo.com/documents/recent/PYu-RC_Group_51_RoHS_L_7.pdf; Component Kind: Standard; Case Code (Metric): 0603; Case Code (Imperial): 0201; Case/Package: 0201; Library Name: LimeFPGAboard_schLib.SchLib
R198 = Res_0402: Part Description: 47 kOhms ±1% 0.05W, 1/20W Chip Resistor 0201 (0603 Metric) Moisture Resistant Thick Film; Manufacturer Part Number 1: RC0201FR-0747KL; Manufacturer: Yageo; Voltage Rating: 25V; Temperature Coefficient: 200ppm/°C; Resistance: 47kR; Power Rating: 50mW; Width: 0.3mm; Weight: 6E-06oz; Value: 47k, 1%; Termination: SMD/SMT; Supplier Part Number 1: 311-47KMCT-ND; Supplier 1: Digi-Key; Stock 1: 684352; Size Code: 0201; Series: RC; RoHS Compliant: Yes; RoHS: Yes; Resistor Type: Thick Film; Resistance Tolerance: 1%; Radiation Hardening: No; Pricing 1: 1=0,10000, 10=0,03700, 100=0,01500, 1000=0,00672, 2500=0,00583, 5000=0,00482 (USD); Packaging: Tape and Reel; Package Quantity: 10000; Number of Terminations: 2; Min Operating Temperature: -55°C; Military Standard: Not; Max Power Dissipation: 50mW; Max Operating Temperature: 125°C; Length: 0.6mm; Lead Free: Lead Free; Height: 0.01inch; Features: Moisture Resistant; Depth: 0.3mm; Contact Plating: Tin,Matte; Construction: Rectangular; ComponentLink7URL: https://datasheet.ciiva.com/8889/pyu-rc0201-51-rohs-l-8-8889065.pdf?src-supplier=Avnet; ComponentLink7Description: https://datasheet.ciiva.com/8889/pyu-rc0201-51-rohs-l-8-8889065.pdf?src-supplier=Avnet; ComponentLink6URL: https://datasheet.ciiva.com/26829/getdatasheetpartid-422496-26829867.pdf?src-supplier=Verical; ComponentLink6Description: https://datasheet.ciiva.com/26829/getdatasheetpartid-422496-26829867.pdf?src-supplier=Verical; ComponentLink5URL: https://datasheet.ciiva.com/25647/pyu-r-int-thick-7-25647310.pdf?src-supplier=Digi-Key; ComponentLink5Description: https://datasheet.ciiva.com/25647/pyu-r-int-thick-7-25647310.pdf?src-supplier=Digi-Key; ComponentLink4URL: https://datasheet.ciiva.com/29710/pyu-r-int-thick-7-29710920.pdf?src-supplier=Digi-Key; ComponentLink4Description: https://datasheet.ciiva.com/29710/pyu-r-int-thick-7-29710920.pdf?src-supplier=Digi-Key; ComponentLink3URL: https://datasheet.ciiva.com/29710/pyu-r-int-thick-7-29710919.pdf?src-supplier=Digi-Key; ComponentLink3Description: https://datasheet.ciiva.com/29710/pyu-r-int-thick-7-29710919.pdf?src-supplier=Digi-Key; ComponentLink2URL: https://datasheet.ciiva.com/7672/1563626-7672232.pdf?src-supplier=Element14; ComponentLink2Description: https://datasheet.ciiva.com/7672/1563626-7672232.pdf?src-supplier=Element14; ComponentLink1URL: http://www.yageo.com/documents/recent/PYu-RC_Group_51_RoHS_L_7.pdf; ComponentLink1Description: http://www.yageo.com/documents/recent/PYu-RC_Group_51_RoHS_L_7.pdf; Component Kind: Standard; Case Code (Metric): 0603; Case Code (Imperial): 0201; Case/Package: 0201; Library Name: LimeFPGAboard_schLib.SchLib
R199 R214 = Res_0402: Part Description: 100 kOhms ±1% 0.05W, 1/20W Chip Resistor 0201 (0603 Metric) Moisture Resistant Thick Film; Manufacturer Part Number 1: RC0201FR-07100KL; Manufacturer: Yageo; Voltage Rating (DC): 25V; Temperature Coefficient: 200ppm/°C; Resistance: 100kR; Power Rating: 50mW; Width: 0.012inch; Voltage Rating: 25V; Value: 100k, 1%; Termination: SMD/SMT; Supplier Part Number 1: 311-100KMCT-ND; Supplier 1: Digi-Key; Stock 1: 6001055; Size Code: 0201; Series: RC; RoHS Compliant: Yes; RoHS: Yes; Resistor Type: Thick Film; Resistance Tolerance: 1%; Reach SVHC Compliant: Yes; Pricing 1: 1=0,10000, 10=0,03700, 100=0,01500, 1000=0,00672, 2500=0,00583, 5000=0,00482 (USD); Packaging: Tape and Reel; Package Quantity: 10000; Number of Terminations: 2; Number of Pins: 2; Min Operating Temperature: -55°C; Military Standard: Not; Max Power Dissipation: 50mW; Max Operating Temperature: 125°C; Length: 0.024inch; Lead Free: Lead Free; Height: 0.01inch; Features: Moisture Resistant; Depth: 0.3mm; Contact Plating: Tin,Matte; Construction: Rectangular; ComponentLink12URL: https://datasheet.ciiva.com/26829/getdatasheetpartid-420441-26829850.pdf?src-supplier=Verical; ComponentLink12Description: https://datasheet.ciiva.com/26829/getdatasheetpartid-420441-26829850.pdf?src-supplier=Verical; ComponentLink11URL: https://datasheet.ciiva.com/2493/pyu-r-int-thick-7-2493769.pdf?src-supplier=Digi-Key; ComponentLink11Description: https://datasheet.ciiva.com/2493/pyu-r-int-thick-7-2493769.pdf?src-supplier=Digi-Key; ComponentLink10URL: https://datasheet.ciiva.com/2493/pyu-r-int-thick-7-2493771.pdf?src-supplier=Digi-Key; ComponentLink10Description: https://datasheet.ciiva.com/2493/pyu-r-int-thick-7-2493771.pdf?src-supplier=Digi-Key; ComponentLink9URL: https://datasheet.ciiva.com/2493/pyu-r-int-thick-7-2493770.pdf?src-supplier=Digi-Key; ComponentLink9Description: https://datasheet.ciiva.com/2493/pyu-r-int-thick-7-2493770.pdf?src-supplier=Digi-Key; ComponentLink8URL: https://datasheet.ciiva.com/46391/1421516-46391338.pdf?src-supplier=Element14; ComponentLink8Description: https://datasheet.ciiva.com/46391/1421516-46391338.pdf?src-supplier=Element14; ComponentLink7URL: https://datasheet.ciiva.com/22698/1563626-22698495.pdf?src-supplier=Element14; ComponentLink7Description: https://datasheet.ciiva.com/22698/1563626-22698495.pdf?src-supplier=Element14; ComponentLink6URL: https://datasheet.ciiva.com/7660/1421516-7660806.pdf?src-supplier=Element14; ComponentLink6Description: https://datasheet.ciiva.com/7660/1421516-7660806.pdf?src-supplier=Element14; ComponentLink5URL: https://datasheet.ciiva.com/8896/pyu-rc0201-51-rohs-l-8-8896174.pdf?src-supplier=Avnet; ComponentLink5Description: https://datasheet.ciiva.com/8896/pyu-rc0201-51-rohs-l-8-8896174.pdf?src-supplier=Avnet; ComponentLink4URL: https://datasheet.ciiva.com/29721/pyu-r-int-thick-7-29721195.pdf?src-supplier=Digi-Key; ComponentLink4Description: https://datasheet.ciiva.com/29721/pyu-r-int-thick-7-29721195.pdf?src-supplier=Digi-Key; ComponentLink3URL: https://datasheet.ciiva.com/29721/pyu-r-int-thick-7-29721196.pdf?src-supplier=Digi-Key; ComponentLink3Description: https://datasheet.ciiva.com/29721/pyu-r-int-thick-7-29721196.pdf?src-supplier=Digi-Key; ComponentLink2URL: https://datasheet.ciiva.com/22698/1563626-22698505.pdf?src-supplier=Element14; ComponentLink2Description: https://datasheet.ciiva.com/22698/1563626-22698505.pdf?src-supplier=Element14; ComponentLink1URL: https://datasheet.ciiva.com/7672/1563626-7672204.pdf?src-supplier=Element14; ComponentLink1Description: https://datasheet.ciiva.com/7672/1563626-7672204.pdf?src-supplier=Element14; Component Kind: Standard; Case Code (Metric): 0603; Case Code (Imperial): 0201; Case/Package: 0201; Library Name: LimeFPGAboard_schLib.SchLib
R201 R202 R204 R205 = Res_0402: Part Description: RES SMD 0.0OHM JUMPER 1/16W 0402; Manufacturer Part Number 1: RC0402JR-070RL; Manufacturer: Yageo; Tolerance: Jumper; Resistance (Ohms): 0.0; Power (Watts): 0.063W, 1/16W; Value: 0R; Temperature Coefficient: -; Supplier Part Number 1: 311-0.0JRTR-ND; Supplier Device Package: 0402; Supplier 1: Digi-Key; Size / Dimension: 0.039" L x 0.020" W (1.00mm x 0.50mm); RoHS: RoHS Compliant; Packaging: Tape & Reel (TR); Package / Case: 0402 (1005 Metric); Operating Temperature: -55°C ~ 155°C; Number of Terminations: 2; Height: 0.016" (0.40mm); Features: Moisture Resistant; Composition: Thick Film; Component Kind: Standard; Category: Resistors; Library Name: LimeMicroAltiumLib_schLib.SchLib
R207 = Res_0402: Part Description: 12.7 kOhms ±1% 0.05W, 1/20W Chip Resistor 0201 (0603 Metric) Moisture Resistant Thick Film; Manufacturer Part Number 1: RC0201FR-0712K7L; Manufacturer: Yageo; Temperature Coefficient: 200ppm/°C; Resistance: 12.7kR; Max Power Dissipation: 50mW; Width: 0.012inch; Value: 12.7k, 1%; Supplier Part Number 1: 311-12.7KMCT-ND; Supplier 1: Digi-Key; Stock 1: 49027; Series: RC; RoHS Compliant: Yes; RoHS: Yes; Resistor Type: Thick Film; Pricing 1: 1=0,10000, 10=0,03700, 100=0,01500, 1000=0,00672, 2500=0,00583, 5000=0,00482 (USD); Packaging: Tape and Reel; Package Quantity: 1; Number of Terminations: 2; Min Operating Temperature: -55°C; Max Operating Temperature: 125°C; Length: 0.024inch; Height: 0.01inch; Features: Moisture Resistant; ComponentLink10URL: https://datasheet.ciiva.com/2493/pyu-r-int-thick-7-2493805.pdf?src-supplier=Digi-Key; ComponentLink10Description: https://datasheet.ciiva.com/2493/pyu-r-int-thick-7-2493805.pdf?src-supplier=Digi-Key; ComponentLink9URL: https://datasheet.ciiva.com/2493/pyu-r-int-thick-7-2493807.pdf?src-supplier=Digi-Key; ComponentLink9Description: https://datasheet.ciiva.com/2493/pyu-r-int-thick-7-2493807.pdf?src-supplier=Digi-Key; ComponentLink8URL: https://datasheet.ciiva.com/2493/pyu-r-int-thick-7-2493806.pdf?src-supplier=Digi-Key; ComponentLink8Description: https://datasheet.ciiva.com/2493/pyu-r-int-thick-7-2493806.pdf?src-supplier=Digi-Key; ComponentLink7URL: https://datasheet.ciiva.com/2467/pyu-r-int-thick-7-2467109.pdf?src-supplier=Digi-Key; ComponentLink7Description: https://datasheet.ciiva.com/2467/pyu-r-int-thick-7-2467109.pdf?src-supplier=Digi-Key; ComponentLink6URL: https://datasheet.ciiva.com/2467/pyu-r-int-thick-7-2467111.pdf?src-supplier=Digi-Key; ComponentLink6Description: https://datasheet.ciiva.com/2467/pyu-r-int-thick-7-2467111.pdf?src-supplier=Digi-Key; ComponentLink5URL: https://datasheet.ciiva.com/2467/pyu-r-int-thick-7-2467110.pdf?src-supplier=Digi-Key; ComponentLink5Description: https://datasheet.ciiva.com/2467/pyu-r-int-thick-7-2467110.pdf?src-supplier=Digi-Key; ComponentLink4URL: https://datasheet.ciiva.com/46391/1421516-46391339.pdf?src-supplier=Element14; ComponentLink4Description: https://datasheet.ciiva.com/46391/1421516-46391339.pdf?src-supplier=Element14; ComponentLink3URL: https://datasheet.ciiva.com/7660/1421516-7660807.pdf?src-supplier=Element14; ComponentLink3Description: https://datasheet.ciiva.com/7660/1421516-7660807.pdf?src-supplier=Element14; ComponentLink2URL: https://datasheet.ciiva.com/22661/1563626-22661319.pdf?src-supplier=Element14; ComponentLink2Description: https://datasheet.ciiva.com/22661/1563626-22661319.pdf?src-supplier=Element14; ComponentLink1URL: https://datasheet.ciiva.com/5924/1563626-5924912.pdf?src-supplier=Farnell; ComponentLink1Description: https://datasheet.ciiva.com/5924/1563626-5924912.pdf?src-supplier=Farnell; Component Kind: Standard; Case Code (Metric): 0603; Case Code (Imperial): 0201; Case/Package: 0201; Library Name: LimeFPGAboard_schLib.SchLib
R211 = Res_0402: Part Description: 31.6 kOhms ±1% 0.05W, 1/20W Chip Resistor 0201 (0603 Metric) Moisture Resistant Thick Film; Manufacturer Part Number 1: RC0201FR-0731K6L; Manufacturer: Yageo; Temperature Coefficient: 200ppm/°C; Resistance: 31.6kR; Max Power Dissipation: 50mW; Width: 0.012inch; Value: 31.6k, 1%; Supplier Part Number 1: YAG2597CT-ND; Supplier 1: Digi-Key; Stock 1: 255391; RoHS Compliant: Yes; RoHS: Yes; Resistor Type: Thick Film; Pricing 1: 1=0,10000, 10=0,03700, 100=0,01500, 1000=0,00672, 2500=0,00583, 5000=0,00482 (USD); Packaging: Tape and Reel; Number of Terminations: 2; Min Operating Temperature: -55°C; Max Operating Temperature: 125°C; Length: 0.024inch; Height: 0.01inch; Features: Moisture Resistant; ComponentLink10URL: http://www.yageo.com/documents/recent/PYu-RC_Group_51_RoHS_L_9.pdf?src-supplier=Digi-Key; ComponentLink10Description: http://www.yageo.com/documents/recent/PYu-RC_Group_51_RoHS_L_9.pdf?src-supplier=Digi-Key; ComponentLink9URL: https://www.yageo.com/upload/media/product/productsearch/datasheet/rchip/PYu-RC_Group_51_RoHS_L_11.pdf?src-supplier=Digi-Key; ComponentLink9Description: https://www.yageo.com/upload/media/product/productsearch/datasheet/rchip/PYu-RC_Group_51_RoHS_L_11.pdf?src-supplier=Digi-Key; ComponentLink8URL: http://www.yageo.com/documents/recent/PYu-RC_Group_51_RoHS_L_10.pdf?src-supplier=Digi-Key; ComponentLink8Description: http://www.yageo.com/documents/recent/PYu-RC_Group_51_RoHS_L_10.pdf?src-supplier=Digi-Key; ComponentLink7URL: https://datasheet.ciiva.com/2467/pyu-r-int-thick-7-2467109.pdf?src-supplier=Digi-Key; ComponentLink7Description: https://datasheet.ciiva.com/2467/pyu-r-int-thick-7-2467109.pdf?src-supplier=Digi-Key; ComponentLink6URL: https://datasheet.ciiva.com/2467/pyu-r-int-thick-7-2467111.pdf?src-supplier=Digi-Key; ComponentLink6Description: https://datasheet.ciiva.com/2467/pyu-r-int-thick-7-2467111.pdf?src-supplier=Digi-Key; ComponentLink5URL: https://datasheet.ciiva.com/2467/pyu-r-int-thick-7-2467110.pdf?src-supplier=Digi-Key; ComponentLink5Description: https://datasheet.ciiva.com/2467/pyu-r-int-thick-7-2467110.pdf?src-supplier=Digi-Key; ComponentLink4URL: https://datasheet.ciiva.com/46391/1421516-46391339.pdf?src-supplier=Element14; ComponentLink4Description: https://datasheet.ciiva.com/46391/1421516-46391339.pdf?src-supplier=Element14; ComponentLink3URL: https://datasheet.ciiva.com/7660/1421516-7660807.pdf?src-supplier=Element14; ComponentLink3Description: https://datasheet.ciiva.com/7660/1421516-7660807.pdf?src-supplier=Element14; ComponentLink2URL: https://datasheet.ciiva.com/22661/1563626-22661319.pdf?src-supplier=Element14; ComponentLink2Description: https://datasheet.ciiva.com/22661/1563626-22661319.pdf?src-supplier=Element14; ComponentLink1URL: https://datasheet.ciiva.com/5924/1563626-5924912.pdf?src-supplier=Farnell; ComponentLink1Description: https://datasheet.ciiva.com/5924/1563626-5924912.pdf?src-supplier=Farnell; Component Kind: Standard; Case Code (Metric): 0603; Case Code (Imperial): 0201; Case/Package: 0201; Library Name: LimeFPGAboard_schLib.SchLib
R217 = Res_0402: Part Description: 4.7 kOhms ±1% 0.05W, 1/20W Chip Resistor 0201 (0603 Metric) Moisture Resistant Thick Film; Manufacturer Part Number 1: RC0201FR-074K7L; Manufacturer: Yageo; Voltage Rating: 25V; Temperature Coefficient: 200ppm/°C; Resistance: 4.7kR; Power Rating: 50mW; Width: 0.012inch; Weight: 6E-06oz; Value: 4.7k, 1%; Termination: SMD/SMT; Supplier Part Number 1: 311-4.70KMCT-ND; Supplier 1: Digi-Key; Stock 1: 6149397; Size Code: 0201; Series: RC; RoHS Compliant: Yes; RoHS: Yes; Resistor Type: Thick Film; Resistance Tolerance: 1%; Radiation Hardening: No; Pricing 1: 1=0,10000, 10=0,03700, 100=0,01530, 1000=0,00685, 2500=0,00595, 5000=0,00491 (USD); Packaging: Tape and Reel; Package Quantity: 1; Number of Terminations: 2; Min Operating Temperature: -55°C; Military Standard: Not; Max Power Dissipation: 50mW; Max Operating Temperature: 125°C; Length: 0.6mm; Lead Free: Lead Free; Height: 0.01inch; Features: Moisture Resistant; Depth: 0.3mm; Contact Plating: Tin,Matte; Construction: Rectangular; ComponentLink11URL: https://datasheet.ciiva.com/8892/pyu-rc0201-51-rohs-l-8-8892942.pdf?src-supplier=Avnet; ComponentLink11Description: https://datasheet.ciiva.com/8892/pyu-rc0201-51-rohs-l-8-8892942.pdf?src-supplier=Avnet; ComponentLink10URL: https://datasheet.ciiva.com/2493/pyu-r-int-thick-7-2493811.pdf?src-supplier=Digi-Key; ComponentLink10Description: https://datasheet.ciiva.com/2493/pyu-r-int-thick-7-2493811.pdf?src-supplier=Digi-Key; ComponentLink9URL: https://datasheet.ciiva.com/2493/pyu-r-int-thick-7-2493813.pdf?src-supplier=Digi-Key; ComponentLink9Description: https://datasheet.ciiva.com/2493/pyu-r-int-thick-7-2493813.pdf?src-supplier=Digi-Key; ComponentLink8URL: https://datasheet.ciiva.com/2493/pyu-r-int-thick-7-2493812.pdf?src-supplier=Digi-Key; ComponentLink8Description: https://datasheet.ciiva.com/2493/pyu-r-int-thick-7-2493812.pdf?src-supplier=Digi-Key; ComponentLink7URL: https://datasheet.ciiva.com/7672/1563626-7672233.pdf?src-supplier=Element14; ComponentLink7Description: https://datasheet.ciiva.com/7672/1563626-7672233.pdf?src-supplier=Element14; ComponentLink6URL: https://datasheet.ciiva.com/2493/pyu-r-int-thick-7-2493784.pdf?src-supplier=Digi-Key; ComponentLink6Description: https://datasheet.ciiva.com/2493/pyu-r-int-thick-7-2493784.pdf?src-supplier=Digi-Key; ComponentLink5URL: https://datasheet.ciiva.com/2493/pyu-r-int-thick-7-2493786.pdf?src-supplier=Digi-Key; ComponentLink5Description: https://datasheet.ciiva.com/2493/pyu-r-int-thick-7-2493786.pdf?src-supplier=Digi-Key; ComponentLink4URL: https://datasheet.ciiva.com/2493/pyu-r-int-thick-7-2493785.pdf?src-supplier=Digi-Key; ComponentLink4Description: https://datasheet.ciiva.com/2493/pyu-r-int-thick-7-2493785.pdf?src-supplier=Digi-Key; ComponentLink3URL: https://datasheet.ciiva.com/7672/1563626-7672202.pdf?src-supplier=Element14; ComponentLink3Description: https://datasheet.ciiva.com/7672/1563626-7672202.pdf?src-supplier=Element14; ComponentLink2URL: https://datasheet.ciiva.com/22661/1563626-22661319.pdf?src-supplier=Element14; ComponentLink2Description: https://datasheet.ciiva.com/22661/1563626-22661319.pdf?src-supplier=Element14; ComponentLink1URL: https://datasheet.ciiva.com/5924/1563626-5924912.pdf?src-supplier=Farnell; ComponentLink1Description: https://datasheet.ciiva.com/5924/1563626-5924912.pdf?src-supplier=Farnell; Component Kind: Standard; Case Code (Metric): 0603; Case Code (Imperial): 0201; Case/Package: 0201; Library Name: LimeFPGAboard_schLib.SchLib
F1-F8 FL1 FL2 = Fiducial: Component Kind: Standard; Library Name: VGTU_Altium_lib.SchLib
